# Altium schematic sheet DT_AZP1524A1_SCH1[SHEET2]_00A.SchDoc
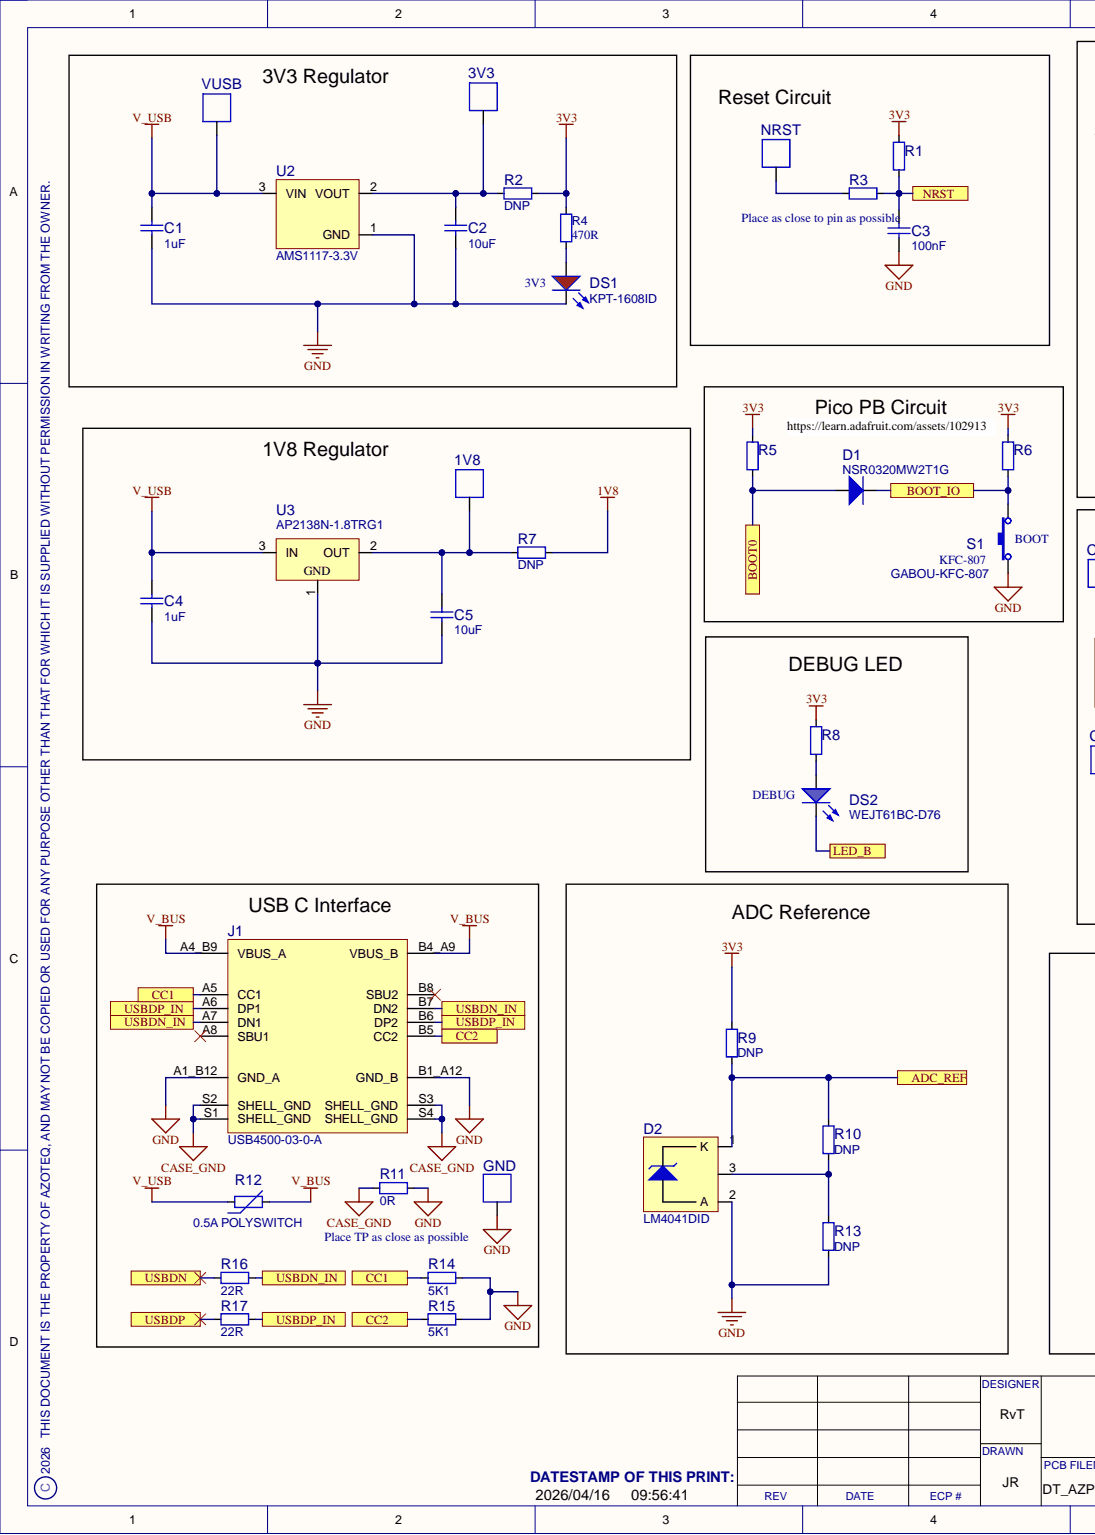
[diagram p0: DT_AZP1524A1_SCH1[SHEET2]_00A.SchDoc, left]
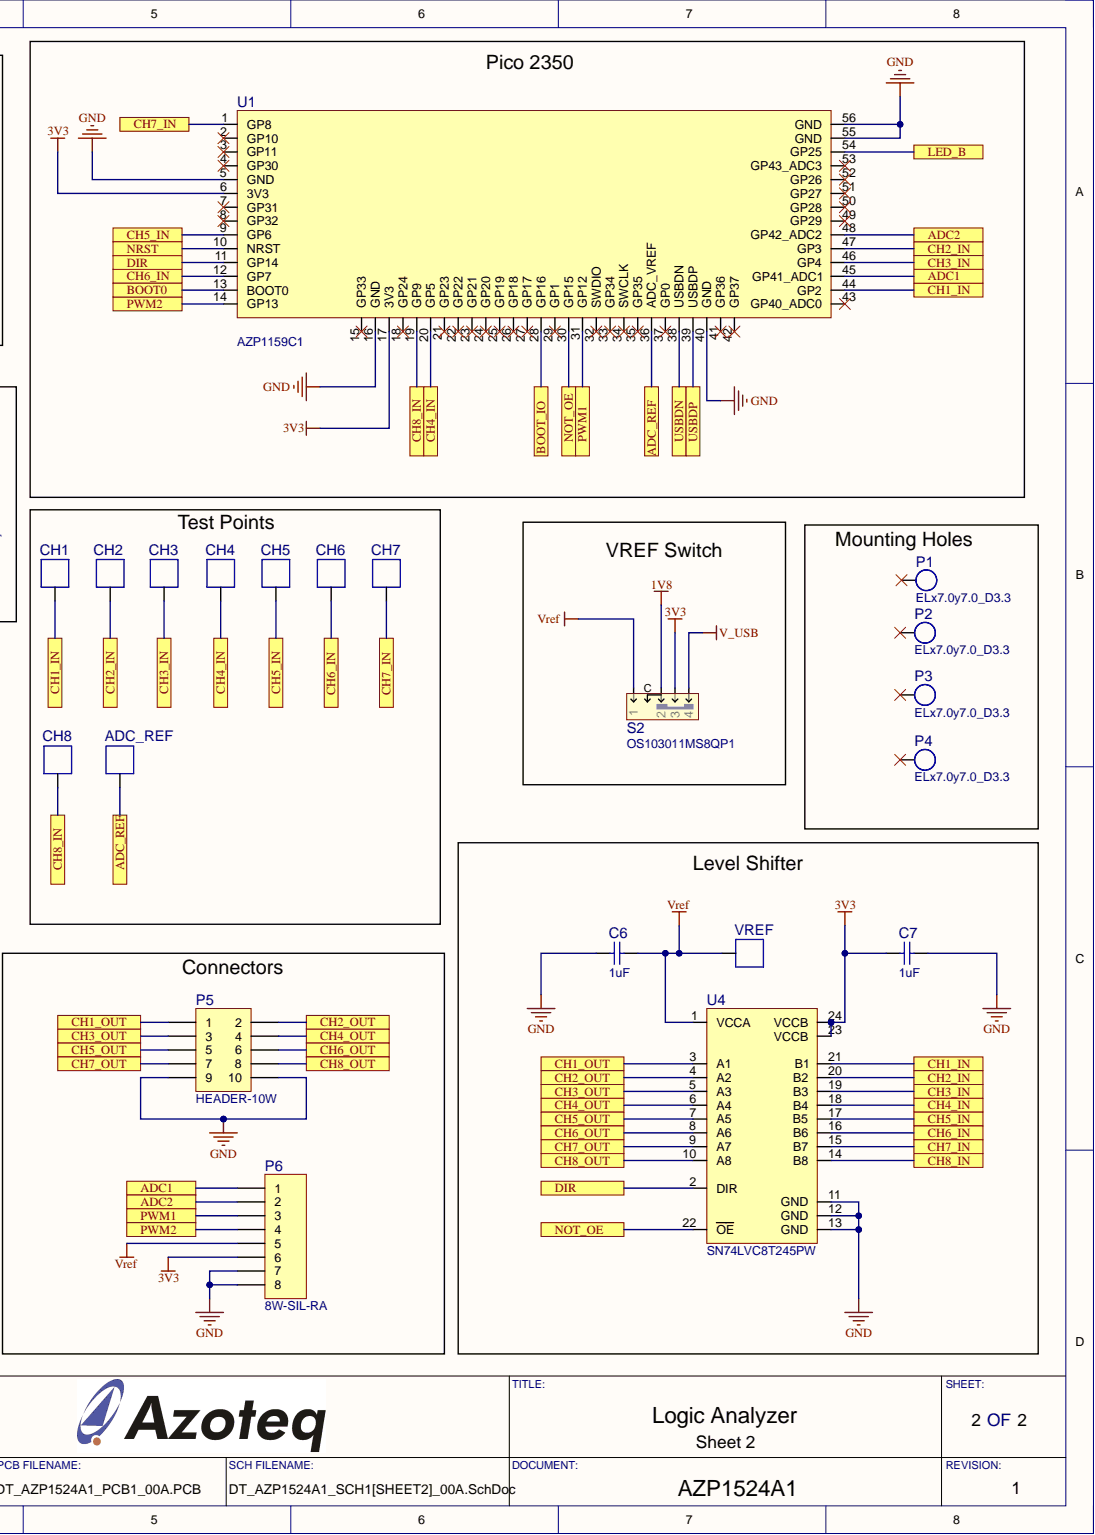
[diagram p1: DT_AZP1524A1_SCH1[SHEET2]_00A.SchDoc, right]

## Parts
1V8  (no value)  [TEST-POINT-1.5MM]  (no BOM)
3V3  (no value)  [TEST-POINT-1.5MM]  (no BOM)
ADC_REF  (no value)  [TEST-POINT-1.5MM]  (no BOM)
C1  1uF  [C-0603]
C2  10uF  [C-0603]
C3  100nF  [C-0603]
C4  1uF  [C-0603]
C5  10uF  [C-0603]
C6  1uF  [C-0603]
C7  1uF  [C-0603]
CH1  (no value)  [TEST-POINT-1.5MM]  (no BOM)
CH2  (no value)  [TEST-POINT-1.5MM]  (no BOM)
CH3  (no value)  [TEST-POINT-1.5MM]  (no BOM)
CH4  (no value)  [TEST-POINT-1.5MM]  (no BOM)
CH5  (no value)  [TEST-POINT-1.5MM]  (no BOM)
CH6  (no value)  [TEST-POINT-1.5MM]  (no BOM)
CH7  (no value)  [TEST-POINT-1.5MM]  (no BOM)
CH8  (no value)  [TEST-POINT-1.5MM]  (no BOM)
D1  NSR0320MW2T1G  [D-SOD-323]
D2  LM4041DID  [SOT-23]
DS1  KPT-1608ID  [D-LED-RED-0603]
DS2  WEJT61BC-D76  [D-LED-BLUE-0603 - WEJ updated]
GND  (no value)  [TEST-POINT-1.5MM]  (no BOM)
J1  USB4500-03-0-A  [J-USB-GCT-USB4500-03-0-A]
NRST  (no value)  [TEST-POINT-1.5MM]  (no BOM)
P1  ELx7.0y7.0_D3.3  [MPAD-X7.0Y7.0_D3.3]  (no BOM)
P2  ELx7.0y7.0_D3.3  [MPAD-X7.0Y7.0_D3.3]  (no BOM)
P3  ELx7.0y7.0_D3.3  [MPAD-X7.0Y7.0_D3.3]  (no BOM)
P4  ELx7.0y7.0_D3.3  [MPAD-X7.0Y7.0_D3.3]  (no BOM)
P5  HEADER-10W  [P-BERG-10W-HDR-EDGE]
P6  8W-SIL-RA  [P-BERG-8W-HDR-RA-SIL]
R1  (no value)  [R-0603]
R2  DNP  [R-0603]
R3  (no value)  [R-0603]
R4  470R  [R-0603]
R5  (no value)  [R-0603]
R6  (no value)  [R-0603]
R7  DNP  [R-0603]
R8  (no value)  [R-0603]
R9  DNP  [R-0603]
R10  DNP  [R-0603]
R11  0R  [R-0603]
R12  0.5A POLYSWITCH  [R-POLYSWITCH-1206]
R13  DNP  [R-0603]
R14  5K1  [R-0603]
R15  5K1  [R-0603]
R16  22R  [R-0603]
R17  22R  [R-0603]
S1  GABOU-KFC-807  value KFC-807  Manufacturer_PN: KFC-807  [S-GABOU-KFC-807]
S2  OS103011MS8QP1  [S-C&K-OS103011MS8QP1]
U1  AZP1159C1  [AZP1159C1]
U2  AMS1117-3.3V  [SOT-223]
U3  AP2138N-1.8TRG1  [SOT-23]
U4  SN74LVC8T245PW  [U-TSSOP-24]
VREF  (no value)  [TEST-POINT-1.5MM]  (no BOM)
VUSB  (no value)  [TEST-POINT-1.5MM]  (no BOM)

## Ports
ADC1, ADC2, ADC_REF, BOOT0, BOOT_IO, CC1, CC2, CH1_IN, CH1_OUT, CH2_IN, CH2_OUT, CH3_IN, CH3_OUT, CH4_IN, CH4_OUT, CH5_IN, CH5_OUT, CH6_IN, CH6_OUT, CH7_IN, CH7_OUT, CH8_IN, CH8_OUT, DIR, LED_B, NOT_OE, NRST, PWM1, PWM2, USBDN, USBDN_IN, USBDP, USBDP_IN

## Nets
1V8: R7.2 S2.2
3V3: C7.2 P6.6 R1.2 R2.2 R4.2 R5.1 R6.1 R8.2 R9.2 S2.3 U1.6(3V3) U1.17(3V3) U4.23(VCCB) U4.24(VCCB)
CASE_GND: J1.S1(SHELL_GND) J1.S2(SHELL_GND) J1.S3(SHELL_GND) J1.S4(SHELL_GND) R11.1
GND: C1.1 C2.1 C3.1 C4.1 C5.1 C6.2 C7.1 D2.2 DS1.1 GND.1 J1.A1_B12(GND_A) J1.B1_A12(GND_B) P5.9 P5.10 P6.7 P6.8 R11.2 R13.1 R14.2 R15.2 S1.1 U1.5(GND) U1.16(GND) U1.40(GND) U1.55(GND) U1.56(GND) U2.1 U3.1 U4.11(GND) U4.12(GND) U4.13(GND)
V_BUS: J1.A4_B9(VBUS_A) J1.B4_A9(VBUS_B) R12.2
V_USB: C1.2 C4.2 R12.1 S2.4 U2.3 U3.3 VUSB.1
Vref: C6.1 P6.5 S2.1 U4.1(VCCA) VREF.1
ADC1: P6.1 U1.45(GP41_ADC1) ; port ADC1
ADC2: P6.2 U1.48(GP42_ADC2) ; port ADC2
ADC_REF: ADC_REF.1 D2.1 R9.1 R10.2 U1.36(ADC_VREF) ; port ADC_REF
BOOT0: D1.2 R5.2 U1.13(BOOT0) ; port BOOT0
BOOT_IO: D1.1 R6.2 S1.2 U1.28(GP16) ; port BOOT_IO
CC1: J1.A5(CC1) R14.1 ; port CC1
CC2: J1.B5(CC2) R15.1 ; port CC2
CH1_IN: CH1.1 U1.44(GP2) U4.21(B1) ; port CH1_IN
CH1_OUT: P5.1 U4.3(A1) ; port CH1_OUT
CH2_IN: CH2.1 U1.47(GP3) U4.20(B2) ; port CH2_IN
CH2_OUT: P5.2 U4.4(A2) ; port CH2_OUT
CH3_IN: CH3.1 U1.46(GP4) U4.19(B3) ; port CH3_IN
CH3_OUT: P5.3 U4.5(A3) ; port CH3_OUT
CH4_IN: CH4.1 U1.20(GP5) U4.18(B4) ; port CH4_IN
CH4_OUT: P5.4 U4.6(A4) ; port CH4_OUT
CH5_IN: CH5.1 U1.9(GP6) U4.17(B5) ; port CH5_IN
CH5_OUT: P5.5 U4.7(A5) ; port CH5_OUT
CH6_IN: CH6.1 U1.12(GP7) U4.16(B6) ; port CH6_IN
CH6_OUT: P5.6 U4.8(A6) ; port CH6_OUT
CH7_IN: CH7.1 U1.1(GP8) U4.15(B7) ; port CH7_IN
CH7_OUT: P5.7 U4.9(A7) ; port CH7_OUT
CH8_IN: CH8.1 U1.19(GP9) U4.14(B8) ; port CH8_IN
CH8_OUT: P5.8 U4.10(A8) ; port CH8_OUT
DIR: U1.11(GP14) U4.2(DIR) ; port DIR
LED_B: DS2.1 U1.54(GP25) ; port LED_B
NOT_OE: U1.30(GP15) U4.22(OE) ; port NOT_OE
NRST: C3.2 R1.1 R3.2 U1.10(NRST) ; port NRST
PWM1: P6.3 U1.31(GP12) ; port PWM1
PWM2: P6.4 U1.14(GP13) ; port PWM2
USBDN: R16.1 U1.38(USBDN) ; port USBDN
USBDN_IN: J1.A7(DN1) J1.B7(DN2) R16.2 ; port USBDN_IN
USBDP: R17.2 U1.39(USBDP) ; port USBDP
USBDP_IN: J1.A6(DP1) J1.B6(DP2) R17.1 ; port USBDP_IN
Net1V8_1: 1V8.1 C5.2 R7.1 U3.2
Net3V3_1: 3V3.1 C2.2 R2.1 U2.2
NetD2_3: D2.3 R10.1 R13.2
NetDS1_2: DS1.2 R4.1
NetDS2_2: DS2.2 R8.1
NetNRST_1: NRST.1 R3.1

Not connected: J1 A8(SBU1) B8(SBU2); P1 1; P2 1; P3 1; P4 1; U1 2(GP10) 3(GP11) 4(GP30) 7(GP31) 8(GP32) 15(GP33) 18(GP24) 21(GP23) 22(GP22) 23(GP21) 24(GP20) 25(GP19) 26(GP18) 27(GP17) 29(GP1) 32(SWDIO) 33(GP34) 34(SWCLK) 35(GP35) 37(GP0) 41(GP36) 42(GP37) 43(GP40_ADC0) 49(GP29) 50(GP28) 51(GP27) 52(GP26) 53(GP43_ADC3)

## Library data of the parts
1V8 3V3 ADC_REF CH1-CH8 GND NRST VREF VUSB = TEST-POINT-SMD-1.5MM: Generated_By: GHM; ComponentLink1Description: Footprint Detail; ComponentLink2Description: Application Note; ComponentLink3Description: Layout Guidelines; Library Name: Miscellaneous.IntLib
C1 C4 C6 C7 = CAP 1u0F 0603 CER X5R 10% 10V: Capacitor, Ceramic, 1uF, 0603, X5R, 10%, 10V; Int_Part_Number: CAP0021; Manufacturer: Samsung; Manufacturer_PN: CL10A105KP8NNNC; 3D Model: YES; Checked_By: Jason Reinecke; Dept.: LightSense; Generated_By: JR; Int_Note: Created for Marike Swart; Pin_Count: 2; Type: SMT; Component Kind: Standard; ComponentLink1Description: Footprint Detail; ComponentLink2Description: Application Note; ComponentLink3Description: Layout Guidelines; Generated_Date: 2023-04-06; HelpURL: \\azoteq-files1\PCBCAD\Datasheets\Capacitors\Samsung\CL10A105KP8NNNC_Spec.pdf; Library Name: Passive.IntLib; Local_Supplier: Mouser; Local_Supplier_PN: 187-CL10A105KP8NNNC; Offshore_Supplier: Digikey; Offshore_Supplier_PN: 1276-1182-2-ND; Supplier: Azoteq; Tolerance: 10%; Value: 1uF; Voltage: 10V
C2 C5 = CAP 10uF 0603 CER X5R 20% 6V3 SAMSUNG: Capacitor, Ceramic, 10uF, 0603, X5R, 20%, 6V3 SAMSUNG; Int_Part_Number: CAP0076; Manufacturer_PN: CL10A106MQ8NNNC; 3D Model: YES; Checked_By: Jason Reinecke; Dept.: LightSense; Generated_By: JR; Int_Note: Created for Marike Swart; Pin_Count: 2; Type: SMT; Component Kind: Standard; ComponentLink1Description: Footprint Detail; ComponentLink2Description: Application Note; ComponentLink3Description: Layout Guidelines; Generated_Date: 2023-04-05; HelpURL: \\Azoteq-files1\pcbcad\Datasheets\Capacitors\TDK Ceramic Mid Voltage.pdf; Library Name: Passive.IntLib; Local_Supplier: Mouser; Local_Supplier_PN: 187-CL10A106MQ8NNNC; Manufacturer: SAMSUNG; Offshore_Supplier: Digikey; Offshore_Supplier_PN: 1276-1119-2-ND; Supplier: Azoteq; Tolerance: 20%; Value: 10uF; Voltage: 6V3
C3 = CAP 100nF 0603 CER X7R 10% 50V: Capacitor, Ceramic, 100nF, 0603, X7R, 10%, 50V; Int_Part_Number: CAP0032; Manufacturer: Samsung; Manufacturer_PN: CL10B104KB8NNNC; 3D Model: YES; Checked_By: Jason Reinecke; Dept.: LightSense; Generated_By: JR; Int_Note: Created for Marike Swart; Pin_Count: 2; Type: SMT; Component Kind: Standard; ComponentLink1Description: Footprint Detail; ComponentLink2Description: Application Note; ComponentLink3Description: Layout Guidelines; Generated_Date: 2023-04-20; HelpURL: \\Azoteq-files1\pcbcad\Datasheets\Capacitors\Samsung Ceramic.pdf; Library Name: Passive.IntLib; Local_Supplier: Mouser; Local_Supplier_PN: 187-CL10B104KB8NNNC; Offshore_Supplier: Digikey; Offshore_Supplier_PN: 1276-1000-2-ND; Supplier: Azoteq; Tolerance: 10%; Value: 100nF; Voltage: 50V
D1 = Diode Schottky 20V 1A SOD-323: Diode Schottky 20V 1A 2-Pin SOD-323 T/R; Int_Part_Number: DIO0074; Manufacturer: ONsemiconductors; Generated_By: JR; Pin_Count: 2; Type: SMT; Component Kind: Standard; ComponentLink1Description: Footprint Detail; ComponentLink2Description: Application Note; ComponentLink3Description: Layout Guidelines; Generated_Date: 2017-09-11; Library Name: Discrete.IntLib; Manufacturer_PN: NSR0320MW2T1G; MSL: 1; Supplier: Azoteq; Value: NSR0320MW2T1G; Voltage: 20V; Wattage: 1A
D2 = DIODE-ZENER-LM4041-SOT-23: Manufacturer: Texas Instruments; Manufacturer_PN: LM4041DIDBZTG4; Generated_By: GHM; Pin_Count: 3; Type: SMT; Component Kind: Standard; ComponentLink1Description: Footprint Detail; ComponentLink2Description: Application Note; ComponentLink3Description: Layout Guidelines; Generated_Date: 2012-03-13; HelpURL: \\Azoteq-files1\pcbcad\Datasheets\Voltage References\Texas Instruments\LM4041DIDBZTG4.pdf; Library Name: Discrete.IntLib; Local_Supplier: RS Components; Local_Supplier_PN: 661-9405; Supplier: Azoteq; Value: LM4041DID
DS1 = LED-KPT1608ID-RED-0603: Manufacturer: KingBright; Generated_By: GHM; Pin_Count: 2; Type: SMT; Component Kind: Standard; ComponentLink1Description: Footprint Detail; ComponentLink2Description: Application Note; ComponentLink3Description: Layout Guidelines; HelpURL: \\Azoteq-files1\pcbcad\Datasheets\LEDs\KingBright\KPT-1608.pdf; Library Name: Discrete.IntLib; Local_Supplier: Communica; Local_Supplier_PN: KPT-1608ID; Manufacturer_PN: KPT-1608ID; Supplier: Azoteq; Value: KPT-1608ID
DS2 = LED-WEJT61BC-D76-BLUE-0603: LED, WEJT61BC-D76, Blue, Vf=3.2V , If=30mA; Int_Part_Number: LED0098; Manufacturer: WEJ; Generated_By: JR; Pin_Count: 2; Type: SMT; Component Kind: Standard; ComponentLink1Description: Footprint Detail; ComponentLink2Description: Application Note; ComponentLink3Description: Layout Guidelines; Generated_Date: 2016-12-02; HelpURL: \\Azoteq-files1\pcbcad\Datasheets\LEDs\WEJT61BC-D76-updated 02-12-2016.pdf; Library Name: Discrete.IntLib; Manufacturer_PN: WEJT61BC-D76; Supplier: Azoteq; Value: WEJT61BC-D76
J1 = USB-GCT-USB4500-03-0-A: USB-C TYPE REC 16P, 3U" MID MNT 0.8MM USB2.0; Int_Part_Number: NONE; Manufacturer: GCT; 3D Model: YES; Checked_By: Jason Reinecke; Dept.: ProxSense; Generated_By: JR; Int_Note: Created for Ferdinand Stegmann; Pin_Count: 16; Tolerance: na; Voltage: 20V; Wattage: 5A; Component Kind: Standard; ComponentLink1Description: Footprint Detail; ComponentLink2Description: Application Note; ComponentLink3Description: Layout Guidelines; Generated_Date: 2022-02-24; HelpURL: \\azoteq-files1\PCBCAD\Datasheets\USB\GCT\usb4500.pdf; Library Name: Connectors.IntLib; Local_Supplier: Mouser; Local_Supplier_PN: 640-USB4500030A; Manufacturer_PN: USB4500-03-0-A; Offshore_Supplier: Digikey; Offshore_Supplier_PN: 2073-USB4500-03-0-ATR-ND; Supplier: Azoteq; Type: SMT; Value: USB4500-03-0-A
P1-P4 = MPAD-ELx7.0y7.0_D3.3: Generated_By: GHM; ComponentLink1Description: Footprint Detail; ComponentLink2Description: Application Note; ComponentLink3Description: Layout Guidelines; Generated_Date: 2013-08-27; Library Name: MPads.IntLib; Value: ELx7.0y7.0_D3.3
P5 = BERG-10W-HDR-EDGE: Connector, Header, Edge Mount,DIL, 10W, SMT; Generated_By: GHM; Pin_Count: 10; Component Kind: Standard; ComponentLink1Description: Footprint Detail; ComponentLink2Description: Application Note; ComponentLink3Description: Layout Guidelines; Generated_Date: 2013-07-29; Library Name: Connectors.IntLib; Local_Supplier: Communica; Supplier: Azoteq; Type: SMT; Value: HEADER-10W
P6 = BERG-8W-HDR-RA-SIL: Connector, Header, Right angle, SIL, 8W, THP; Manufacturer: Ho Chien; Generated_By: GHM; Pin_Count: 8; Component Kind: Standard; ComponentLink1Description: Footprint Detail; ComponentLink2Description: Application Note; ComponentLink3Description: Layout Guidelines; HelpURL: \\azoteq-files1\pcbcad\Datasheets\Headers\Ho Chien Pin Header 2.54mm.pdf; Library Name: Connectors.IntLib; Local_Supplier: Communica; Local_Supplier_PN: 709401; Supplier: Azoteq; Type: THP; Value: 8W-SIL-RA
R1 R3 R5 R6 R8 = MNFR 0603 5% 0.1W: Resistor, 0603, 5%, 0.1W; Int_Part_Number: MNFR04; 3D Model: YES; Checked_By: Jason Reinecke; Generated_By: JR; Pin_Count: 2; Component Kind: Standard; ComponentLink1Description: Footprint Detail; ComponentLink2Description: Application Note; ComponentLink3Description: Layout Guidelines; Generated_Date: 2017-02-01; Library Name: Passive.IntLib; Local_Supplier: Mouser; Offshore_Supplier: Digikey; Simulation: Model Name; Supplier: Assembly Manufacturer; Tolerance: 5%; Type: SMT; Wattage: 0.1W
R2 R7 R9 R10 R13 = RES DNP 0603: Resistor, DNP, 0603; 3D Model: YES; Checked_By: Jason Reinecke; Generated_By: JR; Pin_Count: 2; Component Kind: Standard; ComponentLink1Description: Footprint Detail; ComponentLink2Description: Application Note; ComponentLink3Description: Layout Guidelines; Generated_Date: 2010-01-05; Int_Part_Number: DNP; Library Name: Passive.IntLib; Local_Supplier: Mouser; Offshore_Supplier: Digikey; Supplier: Azoteq; Type: SMT; Value: DNP
R4 = RES 470R 0603 5% 0.1W Yageo: Res Thick Film 0603 470 Ohm 5% 0.1W(1/10W) ±100ppm/°C Molded SMD Paper T/R; Int_Part_Number: RES0307; Manufacturer: Yageo; Manufacturer_PN: RC0603JR-07470RL; 3D Model: YES; Checked_By: Jason Reinecke; Generated_By: JR; Pin_Count: 2; Component Kind: Standard; ComponentLink1Description: Footprint Detail; ComponentLink2Description: Application Note; ComponentLink3Description: Layout Guidelines; Generated_Date: 2017-07-01; Library Name: Passive.IntLib; Local_Supplier: Mouser; Offshore_Supplier: Digikey; Supplier: Azoteq; Tolerance: 5%; Type: SMT; Value: 470R; Wattage: 0.1W
R11 = RES 0R 0603 1% 0.1W Yageo: Res Thick Film 0603 0 Ohm Molded SMD Paper T/R; Int_Part_Number: RES0139; Manufacturer: Yageo; Manufacturer_PN: RC0603JR--070R; 3D Model: YES; Checked_By: Jason Reinecke; Generated_By: JR; Pin_Count: 2; Tolerance: 1%; Wattage: 0.1W; Component Kind: Standard; ComponentLink1Description: Footprint Detail; ComponentLink2Description: Application Note; ComponentLink3Description: Layout Guidelines; Generated_Date: 2009-10-13; Library Name: Passive.IntLib; Local_Supplier: Mouser; Offshore_Supplier: Digikey; Simulation: Model Name; Supplier: Azoteq; Type: SMT; Value: 0R
R12 = POLYSWITCH-nanoSMDC050F/13.2: Polyswitch, nanoSMDC050F, 1206, 0.5A, 13.2V; Int_Part_Number: SWT0070; Manufacturer: Tyco; Checked_By: Jason Reinecke; Generated_By: JR; Pin_Count: 2; Component Kind: Standard; ComponentLink1Description: Footprint Detail; ComponentLink2Description: Application Note; ComponentLink3Description: Layout Guidelines; Generated_Date: 2011-02-25; HelpURL: \\Azoteq-files1\pcbcad\Datasheets\PTC\Tyco Electronics\Raychem PolySwitch 2011.pdf; Library Name: Passive.IntLib; Local_Supplier: Mouser; Local_Supplier_PN: 138-679; Manufacturer_PN: nanoSMDC050F/13.2; Offshore_Supplier: Digikey; Supplier: Azoteq; Type: SMT; Value: 0.5A POLYSWITCH
R14-R17 = MNFR 0603 1% 0.1W: Resistor, 0603, 1%, 0.1W; Int_Part_Number: MNFR03; Generated_By: JR; Pin_Count: 2; Component Kind: Standard; ComponentLink1Description: Footprint Detail; ComponentLink2Description: Application Note; ComponentLink3Description: Layout Guidelines; Generated_Date: 2017-02-01; Library Name: Passive.IntLib; Simulation: Model Name; Supplier: Assembly Manufacturer; Tolerance: 1%; Type: SMT; Wattage: 0.1W
  R14 R15: Value: 5K1
  R16 R17: Value: 22R
S1 = GABOU-KFC-807: SWITCH TACTILE SPST-NO 0.05A 12V; Manufacturer: GABOU; Generated_By: JR; Int_Note: Created for Dylan Els; Pin_Count: 2; Component Kind: Standard; ComponentLink1Description: Footprint Detail; ComponentLink2Description: Application Note; ComponentLink3Description: Layout Guidelines; Generated_Date: 201-06-18; HelpURL: http://www.gaboukeji.com/plus/view.php?aid=2679&vv=cn; Library Name: Switches.IntLib; Supplier: Azoteq; Type: SMT; Voltage: 12V
S2 = C&K-OS103011MS8QP1: SWITCH SLIDE SP3T 100MA 12V; 3D_Part: No; Manufacturer: C&K; Generated_By: JR; Pin_Count: 4; Component Kind: Standard; ComponentLink1Description: Footprint Detail; ComponentLink2Description: Application Note; ComponentLink3Description: Layout Guidelines; Generated_Date: 2018-04-24; HelpURL: \\Azoteq-files1\pcbcad\Datasheets\Switches\C&K\OS103011MS8QP1.pdf; Library Name: Switches.IntLib; Local_Supplier: Digikey; Local_Supplier_PN: CKN9543-ND; Manufacturer_PN: OS103011MS8QP1; Supplier: Azoteq; Type: SMT; Value: OS103011MS8QP1
U1 = AZP1159C1: AZP115C01: RP2350 Stamp (Pi Pico) - stamp breakout; Int_Part_Number: NONE; Manufacturer: Azoteq; 3D Model: YES; Checked_By: Jason Reinecke; Dept.: ProxSense; Generated_By: JR; Int_Note: Created for; Pin_Count: 56; Tolerance: na; Component Kind: Standard; ComponentLink1Description: Footprint Detail; ComponentLink2Description: Application Note; ComponentLink3Description: Layout Guidelines; Generated_Date: 2025-08-07; Library Name: Hardware.IntLib; Local_Supplier: Azoteq; Local_Supplier_PN: AZP1159C1; Manufacturer_PN: AZP1159C1; Offshore_Supplier: Azoteq; Offshore_Supplier_PN: AZP1159C1; Supplier: Azoteq; Type: SMT; Value: AZP1159C1
U2 = AMS1117-MSKSEMI-SOT-223: IC, Voltage Regulator, 3.3V Out, 4.7-18V In, 1A; Int_Part_Number: NONE; 3D Model: YES; Checked_By: Jason Reinecke; Generated_By: JR; Int_Note: Created for Kyle Steyn; Pin_Count: 4; Component Kind: Standard; ComponentLink1Description: Footprint Detail; ComponentLink2Description: Application Note; ComponentLink3Description: Layout Guidelines; Generated_Date: 2024-06-03; HelpURL: \\azoteq-files1\PCBCAD\Datasheets\Voltage Regulators\MSKSEMI\MSKSEMI-AMS1117-3-3V.pdf; Library Name: IC.IntLib; Local_Supplier: Mouser; Local_Supplier_PN: 863-LM1117MPX-33NOPB; Manufacturer: MSKSEMI; Manufacturer_PN: AMS1117-3.3V; Offshore_Supplier: Digikey; Offshore_Supplier_PN: 4518-AMS1117-3.3TR-ND; Supplier: Azoteq; Type: SMT; Value: AMS1117-3.3V; Voltage: 3.3V
U3 = AP2138N-1.8TRG1-SOT-23: IC REG LINEAR 1.8V 250MA SOT23-3; Manufacturer: Diodes Incorporated; 3D Model: YES; Checked_By: Jason Reinecke; Generated_By: JR; Int_Note: Created for Robert Samuel; Pin_Count: 3; Component Kind: Standard; ComponentLink1Description: Footprint Detail; ComponentLink2Description: Application Note; ComponentLink3Description: Layout Guidelines; Generated_Date: 2026-01-16; HelpURL: \\azoteq-files1\PCBCAD\Datasheets\Voltage Regulators\Diodes Inc\AP2138-9.pdf; Library Name: IC.IntLib; Local_Supplier: Mouser; Local_Supplier_PN: 621-AP2138N-1.8TRG1; Manufacturer_PN: AP2138N-1.8TRG1; Offshore_Supplier: Digikey; Offshore_Supplier_PN: AP2138N-1.8TRG1DITR-ND; Supplier: Azoteq; Type: SMT; Value: AP2138N-1.8TRG1; Voltage: 1.8V
U4 = SN74LVC8T245PW-TSSOP-24: Manufacturer: Texas Instruments; Manufacturer_PN: SN74LVC8T245PWG4; Generated_By: GHM; Pin_Count: 24; Component Kind: Standard; ComponentLink1Description: Footprint Detail; ComponentLink2Description: Application Note; ComponentLink3Description: Layout Guidelines; Generated_Date: 2012-03-13; HelpURL: \\Azoteq-files1\pcbcad\Datasheets\Tranceivers\Texas Instruments\SN74LVC8T245PWG4.pdf; Library Name: IC.IntLib; Local_Supplier: RS Components; Local_Supplier_PN: 663-2919; Supplier: Azoteq; Type: SMT; Value: SN74LVC8T245PW
